# Altium schematic sheet [04] - LEDs, SSEG.SchDoc("[04] - LEDs, SSEG")
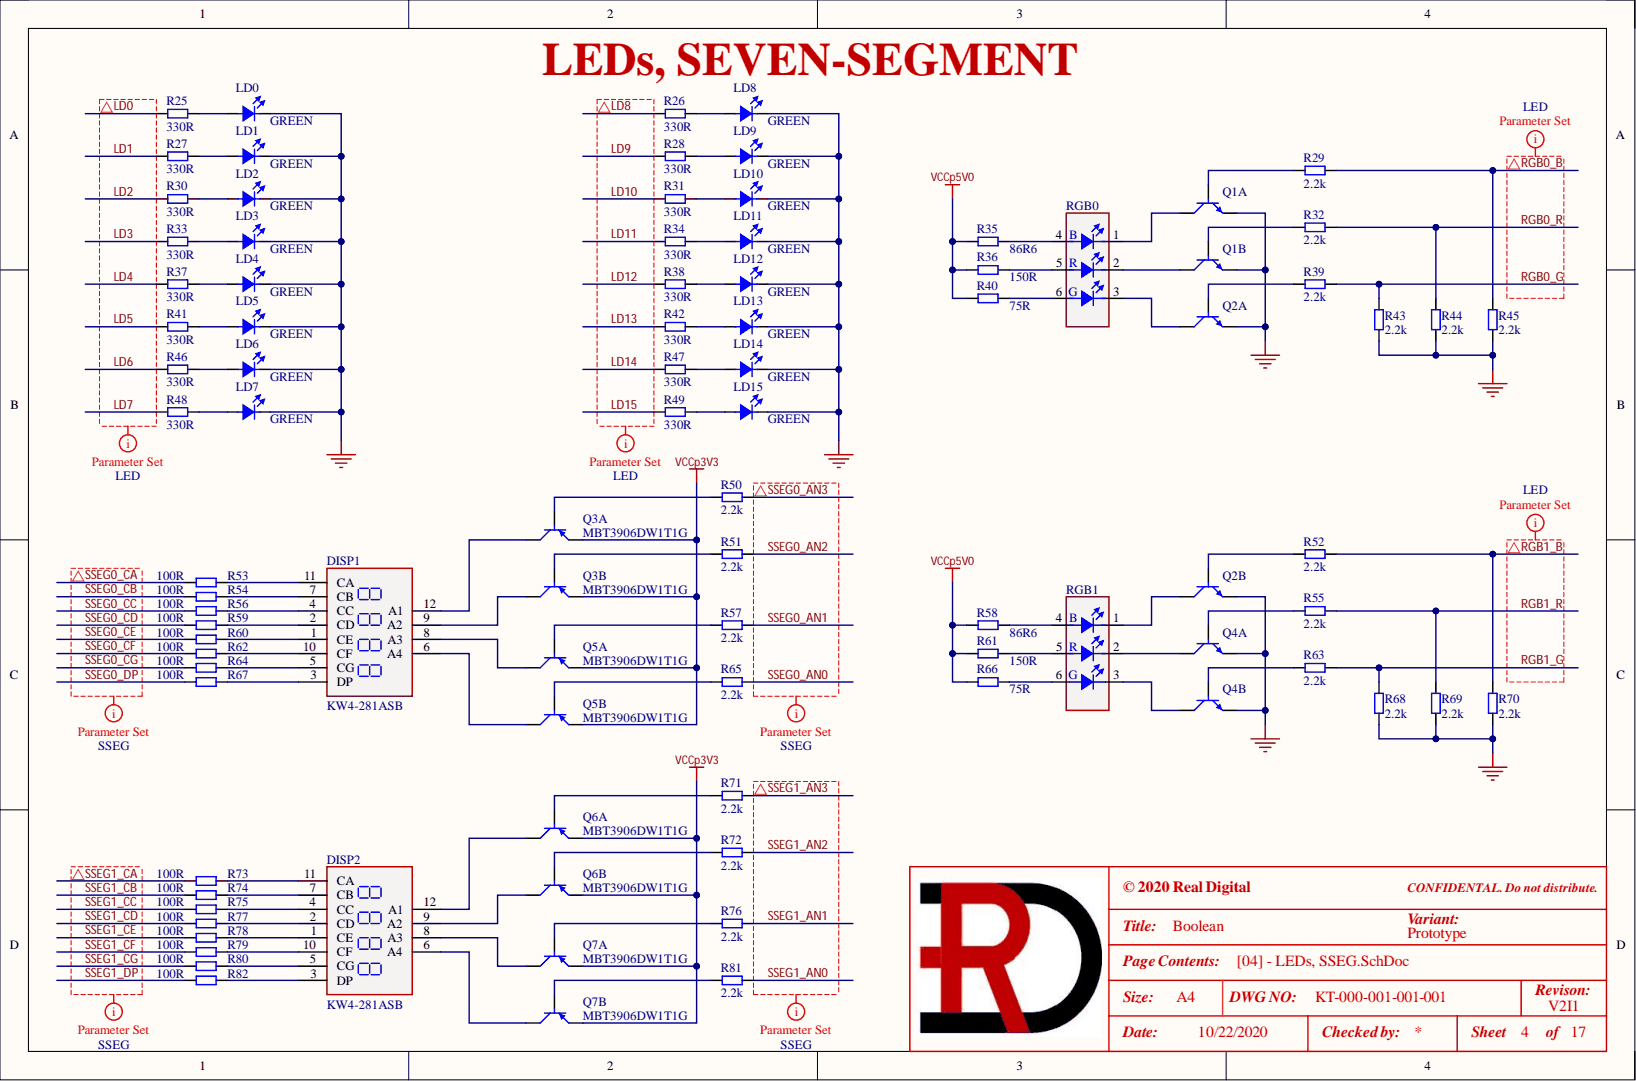
[diagram p0: [04] - LEDs, SSEG.SchDoc]

## Parts
DISP1  KW4-281ASB  [KW4-281ASB]
DISP2  KW4-281ASB  [KW4-281ASB]
LD0  GREEN  [LD0603_Green]
LD1  GREEN  [LD0603_Green]
LD2  GREEN  [LD0603_Green]
LD3  GREEN  [LD0603_Green]
LD4  GREEN  [LD0603_Green]
LD5  GREEN  [LD0603_Green]
LD6  GREEN  [LD0603_Green]
LD7  GREEN  [LD0603_Green]
LD8  GREEN  [LD0603_Green]
LD9  GREEN  [LD0603_Green]
LD10  GREEN  [LD0603_Green]
LD11  GREEN  [LD0603_Green]
LD12  GREEN  [LD0603_Green]
LD13  GREEN  [LD0603_Green]
LD14  GREEN  [LD0603_Green]
LD15  GREEN  [LD0603_Green]
Q1  MBT3904DW1T1G  [SOT-363]  (value not drawn)  (parts A,B)
Q2  MBT3904DW1T1G  [SOT-363]  (value not drawn)  (parts A,B)
Q3  MBT3906DW1T1G  [SOT-363]  (parts A,B)
Q4  MBT3904DW1T1G  [SOT-363]  (value not drawn)  (parts A,B)
Q5  MBT3906DW1T1G  [SOT-363]  (parts A,B)
Q6  MBT3906DW1T1G  [SOT-363]  (parts A,B)
Q7  MBT3906DW1T1G  [SOT-363]  (parts A,B)
R25  330R  [R0402]
R26  330R  [R0402]
R27  330R  [R0402]
R28  330R  [R0402]
R29  2.2k  [R0402]
R30  330R  [R0402]
R31  330R  [R0402]
R32  2.2k  [R0402]
R33  330R  [R0402]
R34  330R  [R0402]
R35  86R6  [R0402]
R36  150R  [R0402]
R37  330R  [R0402]
R38  330R  [R0402]
R39  2.2k  [R0402]
R40  75R  [R0402]
R41  330R  [R0402]
R42  330R  [R0402]
R43  2.2k  [R0402]
R44  2.2k  [R0402]
R45  2.2k  [R0402]
R46  330R  [R0402]
R47  330R  [R0402]
R48  330R  [R0402]
R49  330R  [R0402]
R50  2.2k  [R0402]
R51  2.2k  [R0402]
R52  2.2k  [R0402]
R53  100R  [R0402]
R54  100R  [R0402]
R55  2.2k  [R0402]
R56  100R  [R0402]
R57  2.2k  [R0402]
R58  86R6  [R0402]
R59  100R  [R0402]
R60  100R  [R0402]
R61  150R  [R0402]
R62  100R  [R0402]
R63  2.2k  [R0402]
R64  100R  [R0402]
R65  2.2k  [R0402]
R66  75R  [R0402]
R67  100R  [R0402]
R68  2.2k  [R0402]
R69  2.2k  [R0402]
R70  2.2k  [R0402]
R71  2.2k  [R0402]
R72  2.2k  [R0402]
R73  100R  [R0402]
R74  100R  [R0402]
R75  100R  [R0402]
R76  2.2k  [R0402]
R77  100R  [R0402]
R78  100R  [R0402]
R79  100R  [R0402]
R80  100R  [R0402]
R81  2.2k  [R0402]
R82  100R  [R0402]
RGB0  VS NRD8  [NRD8]  (value not drawn)
RGB1  VS NRD8  [NRD8]  (value not drawn)

## Nets
GND: LD0.K LD1.K LD2.K LD3.K LD4.K LD5.K LD6.K LD7.K LD8.K LD9.K LD10.K LD11.K LD12.K LD13.K LD14.K LD15.K Q1.1 Q1.4 Q2.1 Q2.4 Q4.1 Q4.4 R43.1 R44.1 R45.1 R68.1 R69.1 R70.1
VCCp3V3: Q3.1 Q3.4 Q5.1 Q5.4 Q6.1 Q6.4 Q7.1 Q7.4
VCCp5V0: R35.1 R36.1 R40.1 R58.1 R61.1 R66.1
LD0: R25.1
LD1: R27.1
LD2: R30.1
LD3: R33.1
LD4: R37.1
LD5: R41.1
LD6: R46.1
LD7: R48.1
LD8: R26.1
LD9: R28.1
LD10: R31.1
LD11: R34.1
LD12: R38.1
LD13: R42.1
LD14: R47.1
LD15: R49.1
RGB0_B: R29.2 R45.2
RGB0_G: R39.2 R43.2
RGB0_R: R32.2 R44.2
RGB1_B: R52.2 R70.2
RGB1_G: R63.2 R68.2
RGB1_R: R55.2 R69.2
SSEG0_AN0: R65.2
SSEG0_AN1: R57.2
SSEG0_AN2: R51.2
SSEG0_AN3: R50.2
SSEG0_CA: R53.1
SSEG0_CB: R54.1
SSEG0_CC: R56.1
SSEG0_CD: R59.1
SSEG0_CE: R60.1
SSEG0_CF: R62.1
SSEG0_CG: R64.1
SSEG0_DP: R67.1
SSEG1_AN0: R81.2
SSEG1_AN1: R76.2
SSEG1_AN2: R72.2
SSEG1_AN3: R71.2
SSEG1_CA: R73.1
SSEG1_CB: R74.1
SSEG1_CC: R75.1
SSEG1_CD: R77.1
SSEG1_CE: R78.1
SSEG1_CF: R79.1
SSEG1_CG: R80.1
SSEG1_DP: R82.1
NetDISP1_1: DISP1.1(CE) R60.2
NetDISP1_2: DISP1.2(CD) R59.2
NetDISP1_3: DISP1.3(DP) R67.2
NetDISP1_4: DISP1.4(CC) R56.2
NetDISP1_5: DISP1.5(CG) R64.2
NetDISP1_6: DISP1.6(A4) Q5.6
NetDISP1_7: DISP1.7(CB) R54.2
NetDISP1_8: DISP1.8(A3) Q5.3
NetDISP1_9: DISP1.9(A2) Q3.6
NetDISP1_10: DISP1.10(CF) R62.2
NetDISP1_11: DISP1.11(CA) R53.2
NetDISP1_12: DISP1.12(A1) Q3.3
NetDISP2_1: DISP2.1(CE) R78.2
NetDISP2_2: DISP2.2(CD) R77.2
NetDISP2_3: DISP2.3(DP) R82.2
NetDISP2_4: DISP2.4(CC) R75.2
NetDISP2_5: DISP2.5(CG) R80.2
NetDISP2_6: DISP2.6(A4) Q7.6
NetDISP2_7: DISP2.7(CB) R74.2
NetDISP2_8: DISP2.8(A3) Q7.3
NetDISP2_9: DISP2.9(A2) Q6.6
NetDISP2_10: DISP2.10(CF) R79.2
NetDISP2_11: DISP2.11(CA) R73.2
NetDISP2_12: DISP2.12(A1) Q6.3
NetLD0_A: LD0.A R25.2
NetLD1_A: LD1.A R27.2
NetLD2_A: LD2.A R30.2
NetLD3_A: LD3.A R33.2
NetLD4_A: LD4.A R37.2
NetLD5_A: LD5.A R41.2
NetLD6_A: LD6.A R46.2
NetLD7_A: LD7.A R48.2
NetLD8_A: LD8.A R26.2
NetLD9_A: LD9.A R28.2
NetLD10_A: LD10.A R31.2
NetLD11_A: LD11.A R34.2
NetLD12_A: LD12.A R38.2
NetLD13_A: LD13.A R42.2
NetLD14_A: LD14.A R47.2
NetLD15_A: LD15.A R49.2
NetQ1_2: Q1.2 R32.1
NetQ1_3: Q1.3 RGB0.1(B)
NetQ1_5: Q1.5 R29.1
NetQ1_6: Q1.6 RGB0.2
NetQ2_2: Q2.2 R52.1
NetQ2_3: Q2.3 RGB0.3(G)
NetQ2_5: Q2.5 R39.1
NetQ2_6: Q2.6 RGB1.1
NetQ3_2: Q3.2 R51.1
NetQ3_5: Q3.5 R50.1
NetQ4_2: Q4.2 R63.1
NetQ4_3: Q4.3 RGB1.2
NetQ4_5: Q4.5 R55.1
NetQ4_6: Q4.6 RGB1.3
NetQ5_2: Q5.2 R65.1
NetQ5_5: Q5.5 R57.1
NetQ6_2: Q6.2 R72.1
NetQ6_5: Q6.5 R71.1
NetQ7_2: Q7.2 R81.1
NetQ7_5: Q7.5 R76.1
NetR35_2: R35.2 RGB0.4
NetR36_2: R36.2 RGB0.5
NetR40_2: R40.2 RGB0.6
NetR58_2: R58.2 RGB1.4
NetR61_2: R61.2 RGB1.5
NetR66_2: R66.2 RGB1.6

## Library data of the parts
DISP1 DISP2 = KW4-281ASB: 4 Digit, 12 Pins, Common Anode , 7 Segment Display , Red; Manufacturer 1: Luckylight Electronics Co., Ltd; Manufacturer 2 Part Number: 2841-BSR; .Checked_PCB: AC; .Creator: RV; C P/N: 144-0013; LoadStatus: Load; Category: Display; Component Kind: Standard; Library Name: KT-RealDigital.IntLib; Manufacturer 1 Part Number: KW4-281ASB; Manufacturer 2: Houkem; .Checked_SCH: AC
LD0-LD15 = LED_GREEN: LED GREEN 0603; Manufacturer 1: Everlight Electronics CO., LTD; Manufacturer 1 Part Number: 19-21SYGC/S530-E4/TR8; .Checked_PCB: AC; .Creator: RV; C P/N: 121-0006; LoadStatus: Load; Category: Led; Component Kind: Standard; Library Name: KT-RealDigital.IntLib; .Checked_SCH: AC
Q1 Q2 Q4 = MBT3904DW1T1G: TRANS 2NPN 40V 0.2A SOT-363; Manufacturer 1: ON Semiconductor; .Checked_PCB: AC; .Creator: RV; C P/N: 121-0016; LoadStatus: Load; Category: Transistors; Component Kind: Standard; Library Name: KT-RealDigital.IntLib; Manufacturer 1 Part Number: MBT3904DW1T1G; .Checked_SCH: AC
Q3 Q5-Q7 = MBT3906DW1T1G: TRANS 2PNP 40V 0.2A SOT363; Manufacturer 1: ON Semiconductor; .Checked_PCB: AC; .Creator: RV; C P/N: 121-0032; LoadStatus: Load; Category: Transistors; Component Kind: Standard; Library Name: KT-RealDigital.IntLib; Manufacturer 1 Part Number: MBT3906DW1T1G; .Checked_SCH: AC
R25-R28 R30 R31 R33 R34 R37 R38 R41 R42 R46-R49 = RC0402JR-07330RL: RES SMD 330 OHM 5% 1/16W 0402; Manufacturer 1: Yageo; .Checked_PCB: AC; .Creator: RV; C P/N: 101-0112; LoadStatus: Load; Category: Resistors; Component Kind: Standard; Library Name: KT-RealDigital.IntLib; Manufacturer 1 Part Number: RC0402JR-07330RL; Tolerance: 5%; .Checked_SCH: AC
R29 R32 R39 R43-R45 R50-R52 R55 R57 R63 R65 R68-R72 R76 R81 = RC0402JR-072K2L: RES SMD 2.2K OHM 5% 1/16W 0402; Manufacturer 1: Yageo; .Checked_PCB: AC; .Creator: RV; C P/N: RD-101-0005; LoadStatus: Load; Category: Resistors; Component Kind: Standard; Library Name: KT-RealDigital.IntLib; Manufacturer 1 Part Number: RC0402JR-072K2L; Tolerance: 5%; .Checked_SCH: AC
R35 R58 = RC0402FR-0786R6L: RES SMD 86.6 OHM 1% 1/16W 0402; Manufacturer 1: Yageo; .Checked_PCB: AC; .Creator: RV; C P/N: 101-1068; LoadStatus: Load; Category: Resistors; Component Kind: Standard; Library Name: KT-RealDigital.IntLib; Manufacturer 1 Part Number: RC0402FR-0786R6L; Tolerance: 1%; .Checked_SCH: AC
R36 R61 = RC0402JR-07150RL: RES SMD 150 OHM 5% 1/16W 0402; Manufacturer 1: Yageo; .Checked_PCB: AC; .Creator: RV; C P/N: 101-0141; LoadStatus: Load; Category: Resistors; Component Kind: Standard; Library Name: KT-RealDigital.IntLib; Manufacturer 1 Part Number: RC0402JR-07150RL; Tolerance: 5%; .Checked_SCH: AC
R40 R66 = RC0402JR-0775RL: RES SMD 75 OHM 5% 1/16W 0402; Manufacturer 1: Yageo; .Checked_PCB: AC; .Creator: RV; C P/N: 101-1283; LoadStatus: Load; Category: Resistors; Component Kind: Standard; Library Name: KT-RealDigital.IntLib; Manufacturer 1 Part Number: RC0402JR-0775RL; Tolerance: 5%; .Checked_SCH: AC
R53 R54 R56 R59 R60 R62 R64 R67 R73-R75 R77-R80 R82 = RC0402JR-07100RL: RES SMD 100 OHM 5% 1/16W 0402; Manufacturer 1: Yageo; .Checked_PCB: AC; .Creator: RV; C P/N: 101-0035; LoadStatus: Load; Category: Resistors; Component Kind: Standard; Library Name: KT-RealDigital.IntLib; Manufacturer 1 Part Number: RC0402JR-07100RL; Tolerance: 5%; .Checked_SCH: AC
RGB0 RGB1 = LED_RGB: LED RGB; Manufacturer 1: Victory Electronics; .Creator: RV; C P/N: 121-0264; LoadStatus: Load; Category: Led; Component Kind: Standard; Library Name: KT-RealDigital.IntLib; Manufacturer 1 Part Number: VS NRD8
